# Altium schematic sheet Carte_Batterie 2023.SchDoc("Carte_Batterie 2023")
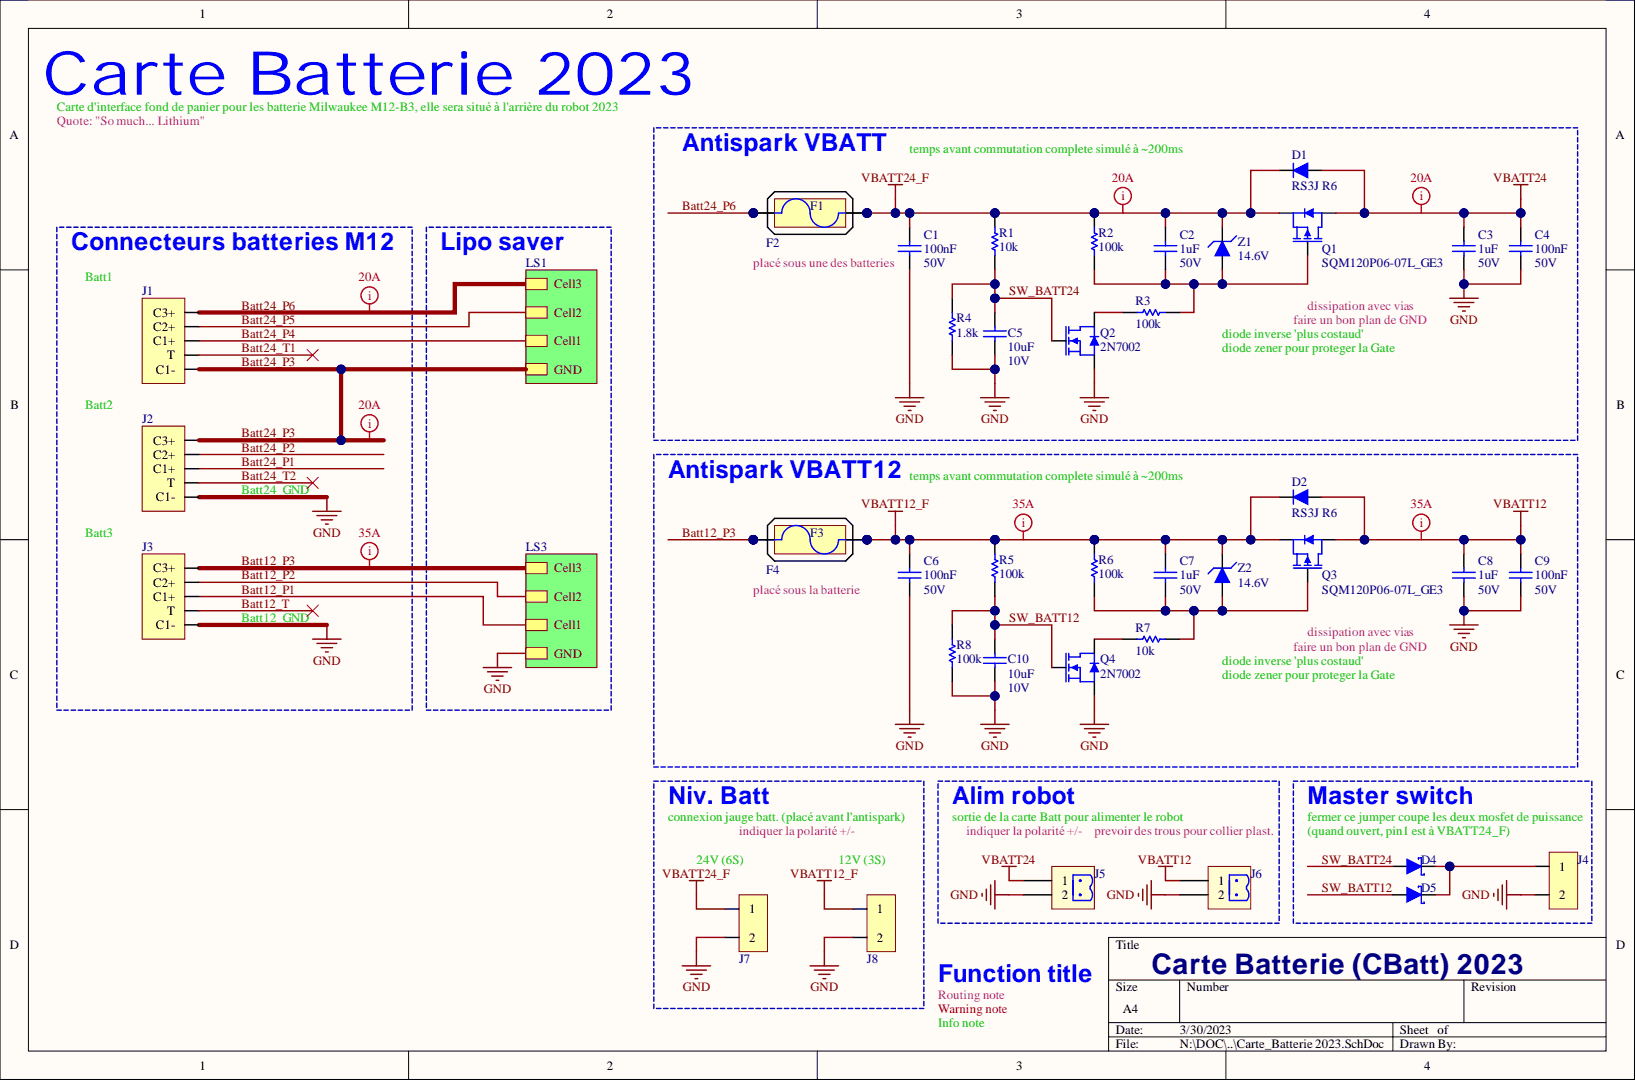
[diagram p0: Carte_Batterie 2023.SchDoc]

## Parts
C1  100nF  RatedVoltage: 50V  [WCAP-CSGP_0603, 1.6x0.8x0.8]
C2  1uF  RatedVoltage: 50V  [WCAP-CSGP_0805, 2x1.25x1.25]
C3  1uF  RatedVoltage: 50V  [WCAP-CSGP_0805, 2x1.25x1.25]
C4  100nF  RatedVoltage: 50V  [WCAP-CSGP_0603, 1.6x0.8x0.8]
C5  10uF  RatedVoltage: 10V  [WCAP-CSGP_0805, 2x1.25x1.25]
C6  100nF  RatedVoltage: 50V  [WCAP-CSGP_0603, 1.6x0.8x0.8]
C7  1uF  RatedVoltage: 50V  [WCAP-CSGP_0805, 2x1.25x1.25]
C8  1uF  RatedVoltage: 50V  [WCAP-CSGP_0805, 2x1.25x1.25]
C9  100nF  RatedVoltage: 50V  [WCAP-CSGP_0603, 1.6x0.8x0.8]
C10  10uF  RatedVoltage: 10V  [WCAP-CSGP_0805, 2x1.25x1.25]
D1  RS3J R6  [SMC, DO-214AB]
D2  RS3J R6  [SMC, DO-214AB]
D4  RBR3MM40ATFTR  [SOD-123FL]  (value not drawn)
D5  RBR3MM40ATFTR  [SOD-123FL]  (value not drawn)
F1  0891030.NXS  (value not drawn)  (mechanical)
F2  3557-2  [3557-2]  (value not drawn)
F3  0891030.NXS  (value not drawn)  (mechanical)
F4  3557-2  [3557-2]  (value not drawn)
J1  custom connector  [CONN_BATT-M12]  (value not drawn)
J2  custom connector  [CONN_BATT-M12]  (value not drawn)
J3  custom connector  [CONN_BATT-M12]  (value not drawn)
J4  22-03-2021  [Conn_22-03-2021]  (value not drawn)
J5  value 2  [691311500102]  (hidden comment: 691311500102)
J6  value 2  [691311500102]  (hidden comment: 691311500102)
J7  22-03-2021  [Conn_22-03-2021]  (value not drawn)
J8  22-03-2021  [Conn_22-03-2021]  (value not drawn)
Q1  SQM120P06-07L_GE3  [TO263AB, D2PAK]
Q2  2N7002  [SOT-23]
Q3  SQM120P06-07L_GE3  [TO263AB, D2PAK]
Q4  2N7002  [SOT-23]
R1  value 10k  [RESC1608X06N]  (hidden comment: RC0603FR-0710KL)
R2  value 100k  [RESC1608X06N]  (hidden comment: RC0603FR-07100KL)
R3  value 100k  [RESC1608X06N]  (hidden comment: RC0603FR-07100KL)
R4  value 1.8k  [RESC1608X06N]  (hidden comment: RC0603FR-071K8L)
R5  value 100k  [RESC1608X06N]  (hidden comment: RC0603FR-07100KL)
R6  value 100k  [RESC1608X06N]  (hidden comment: RC0603FR-07100KL)
R7  value 10k  [RESC1608X06N]  (hidden comment: RC0603FR-0710KL)
R8  value 100k  [RESC1608X06N]  (hidden comment: RC0603FR-07100KL)
Z1  value 14.6V  [SOD-323]  (hidden comment: MM3Z15VST1G)
Z2  value 14.6V  [SOD-323]  (hidden comment: MM3Z15VST1G)

## Nets
GND: C1.1 C3.1 C4.1 C5.1 C6.1 C8.1 C9.1 C10.1 J2.5(C1-) J3.5(C1-) J4.2 J5.2 J6.2 J7.2 J8.2 Q2.2 Q4.2 R4.1 R8.1
VBATT12: C8.2 C9.2 D2.1 J6.1 Q3.4
VBATT12_F: C6.2 C7.2 D2.2 F4.2 F4.4 J8.1 Q3.3 R5.2 R6.2 Z2.2
VBATT24: C3.2 C4.2 D1.1 J5.1 Q1.4
VBATT24_F: C1.2 C2.2 D1.2 F2.2 F2.4 J7.1 Q1.3 R1.2 R2.2 Z1.2
Batt12_P1: J3.3(C1+)
Batt12_P2: J3.2(C2+)
Batt12_P3: F4.1 F4.3 J3.1(C3+)
Batt12_T: J3.4(T)
Batt24_P1: J2.3(C1+)
Batt24_P2: J2.2(C2+)
Batt24_P3: J1.5(C1-) J2.1(C3+)
Batt24_P4: J1.3(C1+)
Batt24_P5: J1.2(C2+)
Batt24_P6: F2.1 F2.3 J1.1(C3+)
Batt24_T1: J1.4(T)
Batt24_T2: J2.4(T)
SW_BATT12: C10.2 D5.1 Q4.1 R5.1 R8.2
SW_BATT24: C5.2 D4.1 Q2.1 R1.1 R4.2
NetC2_1: C2.1 Q1.1 R2.1 R3.1 Z1.1
NetC7_1: C7.1 Q3.1 R6.1 R7.2 Z2.1
NetD4_2: D4.2 D5.2 J4.1
NetQ2_3: Q2.3 R3.2
NetQ4_3: Q4.3 R7.1

## Library data of the parts
C1 C4 C6 C9 = WCAP-CSGP, 885012206095: CAP CER 100nF 50V X7R 0603; Manufacturer: Wurth Elektronik; CeramicType: X7R; Component Kind: Standard; ComponentLink1Description: Datasheet; ComponentLink1URL: http://katalog.we-online.com/pbs/datasheet/885012206095.pdf; Manufacturer Part Number: 885012206095; Size: 0603; Supplier 2: JLCPCB; Supplier Footprint 2: 0603; Supplier Part Number 2: C14663; Value: 100nF; Library Name: Condensateur.IntLib
C2 C3 C7 C8 = WCAP-CSGP, 885012207103: CAP CER 1uF 50V X7R 0805; Manufacturer: Wurth Elektronik; CeramicType: X7R; Component Kind: Standard; ComponentLink1Description: Datasheet; ComponentLink1URL: http://katalog.we-online.com/pbs/datasheet/885012207103.pdf; Manufacturer Part Number: 885012207103; Size: 0805; Supplier 2: JLCPCB; Supplier Footprint 2: 0805; Supplier Part Number 2: C28323; Value: 1uF; Library Name: Condensateur.IntLib
C5 C10 = WCAP-CSGP, 885012207026: CAP CER 10uF 10V X7R 0805; Manufacturer: Wurth Elektronik; CeramicType: X7R; Component Kind: Standard; ComponentLink1Description: Datasheet; ComponentLink1URL: http://katalog.we-online.com/pbs/datasheet/885012207026.pdf; Manufacturer Part Number: 885012207026; Size: 0805; Supplier 1: Farnell; Supplier 2: JLCPCB; Supplier Footprint 2: 0805; Supplier Part Number 1: 2495189; Supplier Part Number 2: C15850; Value: 10uF; Library Name: Condensateur.IntLib
D1 D2 = RS3J_R6: DIODE GEN PURP 600V 3A SMC; Manufacturer: TAIWAN SEMICONDUCTOR; Type: Standard; Component Kind: Standard; ComponentLink1Description: Datasheet; ComponentLink1URL: http://www.farnell.com/datasheets/2182655.pdf; ContinuousForwardCurrent: 3A; Library Name: Diode.IntLib; Manufacturer Part Number: RS3J R6; Supplier 1: Farnell; Supplier Part Number 1: 2677388; Value: 600V
D4 D5 = RBR3MM40ATFTR: DIODE SCHOTTKY 40V 3A SOD123-FL; Manufacturer: ROHM; Component Kind: Standard; ComponentLink1Description: Datasheet; ComponentLink1URL: http://www.farnell.com/datasheets/2067190.pdf; ComponentLink2Description: Manufacturer; ComponentLink2URL: http://www.rohm.com/web/global/products/-/product/RBR3MM40ATF; ContinuousForwardCurrent: 3A; Library Name: Diode.IntLib; Manufacturer Part Number: RBR3MM40ATFTR; Supplier 1: Farnell; Supplier 2: JLCPCB; Supplier Footprint 2: SOD-123; Supplier Part Number 1: 2581593; Supplier Part Number 2: C8598; Type: Schottky; Value: 40V
F1 F3 = 0891030.NXS: FUSE AUTO 30A 58VDC BLADE MINI; Manufacturer: Littelfuse Inc.; ComponentLink1Description: Datasheet; ComponentLink1URL: http://www.littelfuse.com/~/media/automotive/datasheets/fuses/passenger-car-and-commercial-vehicle/blade-fuses/littelfuse_lowprofilemini_datasheet.pdf; Library Name: Fuse.IntLib; Manufacturer Part Number: 0891030.NXS; Supplier 1: Digi-Key; Supplier Part Number 1: F4993-ND; Value: 30A
F2 F4 = 3557-2: FUSE Holder 500V 30A PCB; Manufacturer: Keystone Electronics; Value: na; Component Kind: Standard; ComponentLink1Description: Datasheet; ComponentLink1URL: http://www.keyelco.com/product-pdf.cfm?p=296; Library Name: Fuse.IntLib; Manufacturer Part Number: 3557-2; Supplier 1: Farnell; Supplier Part Number 1: 2292904
J1-J3 = CONN_BATT-M12: Batterie connector for Milwaukee M12, use 2x RS 125-2006 and 3x RS 125-2000; Component Kind: Standard; Library Name: Divers.IntLib
J4 J7 J8 = 22-03-2021: CONN HEADER VERT 2POS 2.54MM; Manufacturer: MOLEX; Component Kind: Standard; ComponentLink1Description: Datasheet; ComponentLink1URL: http://www.farnell.com/datasheets/1791308.pdf; ComponentLink2Description: Drawings; ComponentLink2URL: http://www.farnell.com/cad/495071.pdf  http://www.farnell.com/cad/1533907.pdf  http://www.farnell.com/cad/1533907.pdf; Manufacturer Part Number: 22-03-2021; Supplier 1: Farnell; Supplier Part Number 1: 9731075; Library Name: Connecteurs.IntLib
J5 J6 = 691311500102: WR-TBL 2p Series 311 - 5.08 mm Closed Vertical PCB Header; Manufacturer: Wurth Electronics Inc.; Component Kind: Standard; ComponentLink1Description: Datasheet; ComponentLink1URL: https://katalog.we-online.de/em/datasheet/6913115001xx.pdf; Manufacturer Part Number: 691311500102; Supplier 1: Farnell; Supplier Part Number 1: 1641978; Library Name: Connecteurs.IntLib
Q1 Q3 = SQM120P06-07L_GE3: MOSFET P 60V 120A D2PAK; Manufacturer: VISHAY; Component Kind: Standard; ComponentLink1Description: Datasheet; ComponentLink1URL: http://www.vishay.com/docs/67026/sqm120p0.pdf; Library Name: Transistors.IntLib; Manufacturer Part Number: SQM120P06-07L_GE3; Supplier 1: Farnell; Supplier Part Number 1: 2617099
Q2 Q4 = 2N7002: MOSFET N 60V 115MA SOT23; Manufacturer: Changjiang Electronics Tech (CJ); Component Kind: Standard; ComponentLink1Description: Datasheet; ComponentLink1URL: https://datasheet.lcsc.com/szlcsc/1810151612_Changjiang-Electronics-Tech-CJ-2N7002_C8545.pdf; Library Name: Transistors.IntLib; Manufacturer Part Number: 2N7002; Supplier 1: Farnell; Supplier 2: JLCPCB; Supplier Footprint 2: SOT-23-3; Supplier Part Number 1: 1510761; Supplier Part Number 2: C8545
R1 R7 = RC0603FR-0710KL: RES SMD 10K OHM 1% 1/10W 0603; Manufacturer: Yageo; Power: 100mW; Vmax: 50V; Component Kind: Standard; ComponentLink1Description: Manufacturer; ComponentLink1URL: http://www.yageo.com/NewPortal/_en/index.jsp; ComponentLink2Description: Datasheet; ComponentLink2URL: http://www.farnell.com/datasheets/2167052.pdf; Manufacturer Part Number: RC0603FR-0710KL; Precision: ±1%; Supplier 1: Farnell; Supplier 2: JLCPCB; Supplier Footprint 2: 0603; Supplier Part Number 1: 9238603; Supplier Part Number 2: C25804; Type: Thick Film; Library Name: Resistance.IntLib
R2 R3 R5 R6 R8 = RC0603FR-07100KL: RES SMD 100K OHM 1% 1/10W 0603; Manufacturer: Yageo; Power: 100mW; Vmax: 50V; Component Kind: Standard; ComponentLink1Description: Manufacturer; ComponentLink1URL: http://www.yageo.com/NewPortal/_en/index.jsp; ComponentLink2Description: Datasheet; ComponentLink2URL: http://www.farnell.com/datasheets/2167052.pdf; Manufacturer Part Number: RC0603FR-07100KL; Precision: ±1%; Supplier 1: Farnell; Supplier 2: JLCPCB; Supplier Footprint 2: 0603; Supplier Part Number 1: 2309107; Supplier Part Number 2: C25803; Type: Thick Film; Library Name: Resistance.IntLib
R4 = RC0603FR-071K8L: RES SMD 1.8K OHM 1% 1/10W 0603; Manufacturer: Yageo; Power: 100mW; Vmax: 50V; Component Kind: Standard; ComponentLink1Description: Manufacturer; ComponentLink1URL: http://www.yageo.com/NewPortal/_en/index.jsp; ComponentLink2Description: Datasheet; ComponentLink2URL: http://www.farnell.com/datasheets/2167052.pdf; Manufacturer Part Number: RC0603FR-071K8L; Precision: ±1%; Supplier 1: Farnell; Supplier 2: JLCPCB; Supplier Footprint 2: 0603; Supplier Part Number 1: 9238514; Supplier Part Number 2: C4177; Type: Thick Film; Library Name: Resistance.IntLib
Z1 Z2 = MM3Z15VST1G: DIODE ZENER 14.6V 300mW SOD323; Manufacturer: ON Semiconductor; Component Kind: Standard; ComponentLink1Description: Datasheet; ComponentLink1URL: http://www.farnell.com/datasheets/2028649.pdf; Library Name: Diode.IntLib; Manufacturer Part Number: MM3Z15VST1G; Supplier 1: Farnell; Supplier Part Number 1: 2533369; Type: Zener
